# Altium schematic sheet ICWSHLP2_Adaptor_2x2_Transimpedance_ASIC2.SchDoc(ICWSHLP2_Adaptor_2x2_Transimpedance_ASIC2)
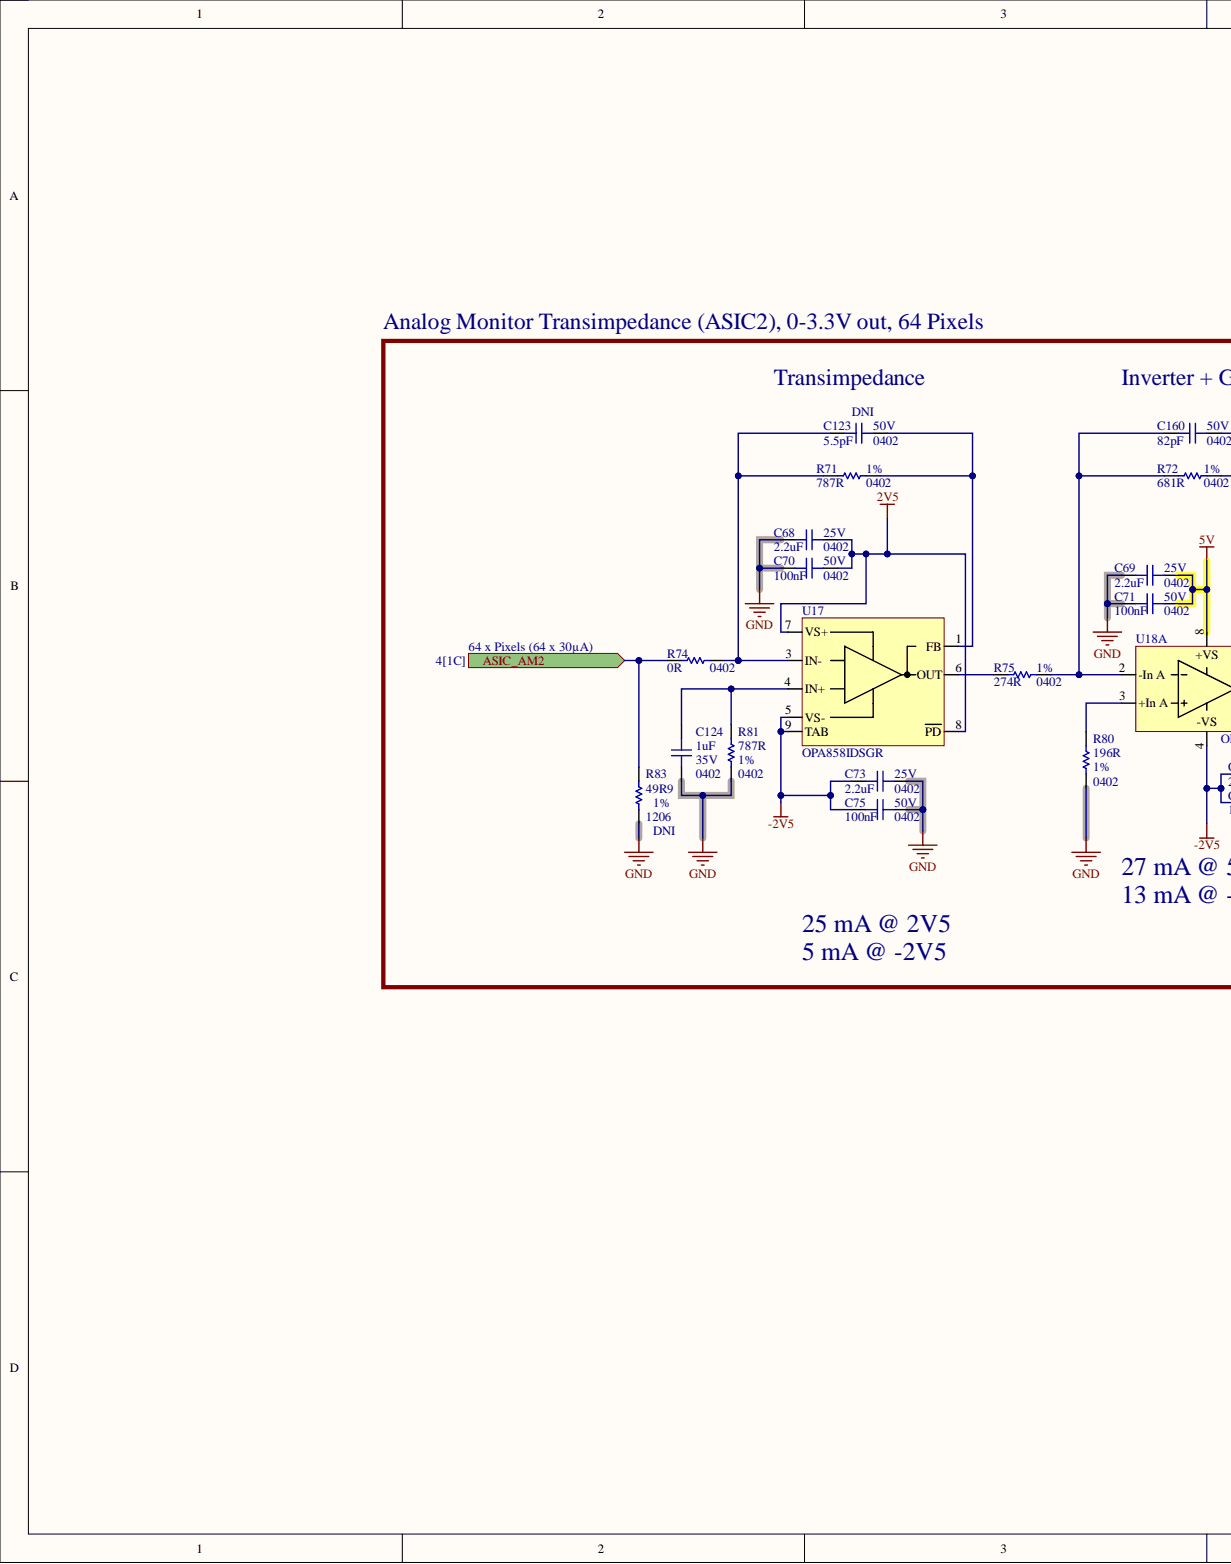
[diagram p0: ICWSHLP2_Adaptor_2x2_Transimpedance_ASIC2.SchDoc, left]
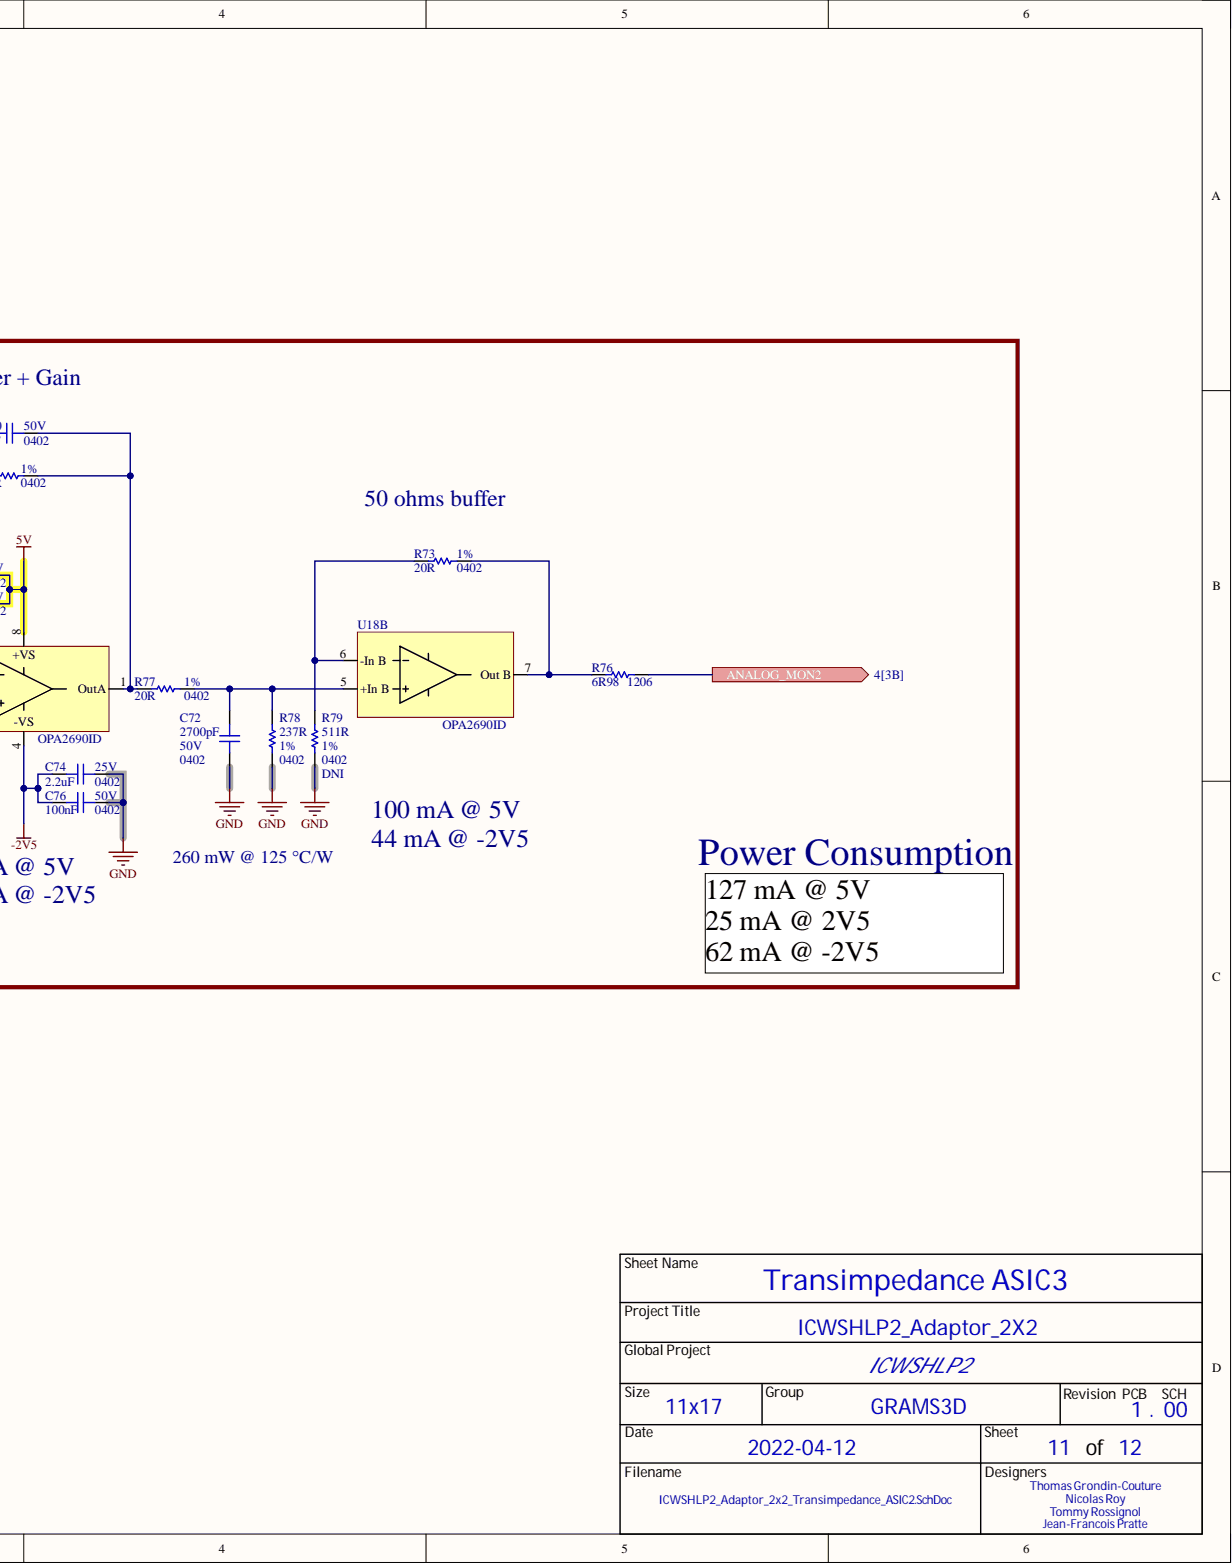
[diagram p1: ICWSHLP2_Adaptor_2x2_Transimpedance_ASIC2.SchDoc, right]

## Parts
C68  value 2.2uF  Package: 0402  Voltage Rating: 25V  [CAP_CER_0402]  (hidden comment: GRT155R61E225KE13D)
C69  value 2.2uF  Package: 0402  Voltage Rating: 25V  [CAP_CER_0402]  (hidden comment: GRT155R61E225KE13D)
C70  value 100nF  Package: 0402  Voltage Rating: 50V  [CAP_CER_0402]  (hidden comment: UMK105B7104KV-FR)
C71  value 100nF  Package: 0402  Voltage Rating: 50V  [CAP_CER_0402]  (hidden comment: UMK105B7104KV-FR)
C72  value 2700pF  Package: 0402  Voltage Rating: 50V  [CAP_CER_0402]  (hidden comment: C0402C272J5RAC7867)
C73  value 2.2uF  Package: 0402  Voltage Rating: 25V  [CAP_CER_0402]  (hidden comment: GRT155R61E225KE13D)
C74  value 2.2uF  Package: 0402  Voltage Rating: 25V  [CAP_CER_0402]  (hidden comment: GRT155R61E225KE13D)
C75  value 100nF  Package: 0402  Voltage Rating: 50V  [CAP_CER_0402]  (hidden comment: UMK105B7104KV-FR)
C76  value 100nF  Package: 0402  Voltage Rating: 50V  [CAP_CER_0402]  (hidden comment: UMK105B7104KV-FR)
C123  value 5.5pF  Assembly Note: DNI  Package: 0402  Voltage Rating: 50V  [CAP_CER_0402]  (hidden comment: GCQ1555C1H5R5BB01D)
C124  value 1uF  Package: 0402  Voltage Rating: 35V  [CAP_CER_0402]  (hidden comment: CL05A105KL5NRNC)
C160  value 82pF  Package: 0402  Voltage Rating: 50V  [CAP_CER_0402]  (hidden comment: 0402N820J500CT)
R71  value 787R  Package: 0402  Tolerance: 1%  [RES_0402]  (hidden comment: RC0402FR-07787RL)
R72  value 681R  Package: 0402  Tolerance: 1%  [RES_0402]  (hidden comment: RC0402FR-07681RL)
R73  value 20R  Package: 0402  Tolerance: 1%  [RES_0402]  (hidden comment: RC0402FR-0720RL)
R74  value 0R  Package: 0402  [RES_0402]  (hidden comment: RC0402JR-070RL)
R75  value 274R  Package: 0402  Tolerance: 1%  [RES_0402]  (hidden comment: ERJ-2RKF2740X)
R76  value 6R98  Package: 1206  [RES_1206]  (hidden comment: RC1206FR-076R98L)
R77  value 20R  Package: 0402  Tolerance: 1%  [RES_0402]  (hidden comment: RC0402FR-0720RL)
R78  value 237R  Package: 0402  Tolerance: 1%  [RES_0402]  (hidden comment: ERJ-2RKF2370X)
R79  value 511R  Assembly Note: DNI  Package: 0402  Tolerance: 1%  [RES_0402]  (hidden comment: RC0402FR-07511RL)
R80  value 196R  Package: 0402  Tolerance: 1%  [RES_0402]  (hidden comment: RC0402FR-07196RL)
R81  value 787R  Package: 0402  Tolerance: 1%  [RES_0402]  (hidden comment: RC0402FR-07787RL)
R83  value 49R9  Assembly Note: DNI  Package: 1206  Tolerance: 1%  [RES_1206]  (hidden comment: RNCP1206FTD49R9RC1206FR-076R98L)
U17  OPA858IDSGR  [AMP_HSP_OPA858IDSGR]
U18  OPA2690ID  [AMP_HSP_OPA2690ID]  (parts A,B)

## Ports
ANALOG_MON2, ASIC_AM2

## Nets
2V5: C68.2 C70.2 U17.7(VS+) U17.8(PD)
5V: C69.2 C71.2 U18.8
-2V5: C73.1 C74.1 C75.1 C76.1 U17.5(VS-) U17.9(TAB) U18.4
GND: C68.1 C69.1 C70.1 C71.1 C72.1 C73.2 C74.2 C75.2 C76.2 C124.1 R78.1 R79.1 R80.1 R81.1 R83.1
ANALOG_MON2: R76.1 ; port ANALOG_MON2
ASIC_AM2: R74.2 R83.2 ; port ASIC_AM2
NetC72_2: C72.2 R77.1 R78.2 U18.5(+In_B)
NetC123_1: C123.1 R71.1 U17.1(FB)
NetC123_2: C123.2 R71.2 R74.1 U17.3(IN-)
NetC124_2: C124.2 R81.2 U17.4(IN+)
NetC160_1: C160.1 R72.1 R77.2 U18.1(OutA)
NetC160_2: C160.2 R72.2 R75.1 U18.2(-In_A)
NetR73_1: R73.1 R76.2 U18.7(Out_B)
NetR73_2: R73.2 R79.2 U18.6(-In_B)
NetR75_2: R75.2 U17.6(OUT)
NetR80_2: R80.2 U18.3(+In_A)

## Library data of the parts
C68 C69 C73 C74 = CAP_CER_GRT155R61E225KE13D: CAP - CER 2.2uF ±10% 25V X5R - 0402; Manufacturer #1: Murata Electronics North America; Cryo: UNKNOWN; GRAMS Lib Spec: 2.8; Note: 2uF@1.5V 1uF@5V 0.55uF@10V; Polarized: No; Assembly Type: SMD; Component Kind: Standard; HelpURL: http://search.murata.co.jp/Ceramy/image/img/A01X/G101/ENG/GRT155R61E225KE13-01.pdf; Library Name: GRAMS_MASTER_LIB.IntLib; Magnetic Material: Unknown; Part Number #1: GRT155R61E225KE13D; Supplier 1: Digi-Key; Supplier Part Number 1: 490-12267-1-ND; Temperature: -55°C ~ 85°C; Tolerance: 10%; CreatedBy/Date: Keven Deslandes - 2018/12/18; VerifiedBy: Caroline Paulin - 23 janv 2019
C70 C71 C75 C76 = CAP_CER_UMK105B7104KV-FR: CAP - CER 100nF ±10% 50V X7R - 0402; Manufacturer #1: Taiyo Yuden; Cryo: UNKNOWN; GRAMS Lib Spec: 2.8; Note: DC bias losses = -25%@5V, -55%@10V; Polarized: No; Assembly Type: SMD; Component Kind: Standard; HelpURL: https://ds.yuden.co.jp/TYCOMPAS/ut/detail?pn=UMK105B7104KV-FR%20%20&u=M; Library Name: GRAMS_MASTER_LIB.IntLib; Magnetic Material: Unknown; Part Number #1: UMK105B7104KV-FR; Supplier 1: Digi-Key; Supplier Part Number 1: 587-3498-1-ND; Temperature: -55°C ~ 125°C; Tolerance: 10%; CreatedBy/Date: Keven Deslandes - 2018/12/18; VerifiedBy: Caroline Paulin - 23 janv 2019
C72 = CAP_CER_C0402C272J5RAC7867: CAP CER 2700PF 50V X7R 0402; Manufacturer #1: KEMET; Cryo: UNKNOWN; GRAMS Lib Spec: 2.8; Polarized: NO; Tolerance: 5%; Assembly Type: SMD; Component Kind: Standard; HelpURL: https://content.kemet.com/datasheets/KEM_C1002_X7R_SMD.pdf; Magnetic Material: UNKNOWN; Part Number #1: C0402C272J5RAC7867; Solder Paste Type: UNKNOWN; Stencil Thickness (Recommended): UNKNOWN; Supplier 1: Digi-Key; Supplier Part Number 1: 399-14438-1-ND; Temperature: -55°C ~ 125°C; CreatedBy/Date: Thomas G-C 2021-06-10; Library Name: Lib_temp_Thomas_GC.SchLib
C123 = CAP_CER_GCQ1555C1H5R5BB01D: CAP - CER 5.5pF +/- 0.1pF 50V C0G/NPO - 0402; Manufacturer #1: Murata Electronics North America; GRAMS Lib Spec: 2.8; Magnetic Material: Unknown; Polarized: NO; Assembly Type: SMD; Component Kind: Standard; HelpURL: https://search.murata.co.jp/Ceramy/image/img/A01X/G101/ENG/GCQ1555C1H5R5BB01-01.pdf; Library Name: GRAMS_MASTER_LIB.IntLib; Part Number #1: GCQ1555C1H5R5BB01D; Supplier 1: Digi-Key; Supplier Part Number 1: 490-17488-1-ND; Temperature: -55°C ~ 125°C; Tolerance: 0.1pF; CreatedBy/Date: Nicolas Roy 2019-04-17
C124 = CAP_CER_CL05A105KL5NRNC: CAP - CER 1uF 10% 35V X5R - 0402; Manufacturer #1: Samsung Electro-Mechanics; Cryo: UNKNOWN; GRAMS Lib Spec: 2.8; Polarized: NO; Assembly Type: SMD; Component Kind: Standard; HelpURL: http://www.samsungsem.com/kr/support/product-search/mlcc/__icsFiles/afieldfile/2018/06/19/CL05A105KL5NRNC.pdf; Library Name: GRAMS_MASTER_LIB.IntLib; Magnetic Material: Unknown; Part Number #1: CL05A105KL5NRNC; Supplier 1: Digi-Key; Supplier Part Number 1: 1276-6796-1-ND; Temperature: -55°C ~ 85°C; Tolerance: 10%; CreatedBy/Date: Nicolas Roy 2018-10-03; VerifiedBy: Caroline Paulin - 18 janv 2019
C160 = CAP_CER_0402N820J500CT: CAP CER 82PF 50V C0G/NP0 0402; Manufacturer #1: Walsin Technology Corporation; Cryo: UNKNOWN; GRAMS Lib Spec: 2.8; Polarized: NO; Tolerance: 5%; Assembly Type: SMD; Component Kind: Standard; HelpURL: http://www.passivecomponent.com/wp-content/uploads/2018/10/MLCC.pdf; Magnetic Material: UNKNOWN; Part Number #1: 0402N820J500CT; Solder Paste Type: UNKNOWN; Stencil Thickness (Recommended): UNKNOWN; Supplier 1: Digi-Key; Supplier Part Number 1: 1292-1355-1-ND; Temperature: -55°C ~ 125°C; CreatedBy/Date: Thomas G-C 2021-06-10; Library Name: Lib_temp_Thomas_GC.SchLib
R71 R81 = RES_RC0402FR-07787RL: RES - 787R 1% 1/16W - 0402; Manufacturer #1: Yageo; GRAMS Lib Spec: 2.8; Magnetic Material: Unknown; Polarized: No; Voltage Rating: 50V; Assembly Type: SMD; ClassName: Transimpedance_ASIC0; Component Kind: Standard; HelpURL: http://www.yageo.com/documents/recent/PYu-RC_Group_51_RoHS_L_10.pdf; Library Name: GRAMS_MASTER_LIB.IntLib; Part Number #1: RC0402FR-07787RL; Power Max: 1/16W; Supplier 1: Digi-Key; Supplier Part Number 1: YAG3233CT-ND; Temperature: -55°C ~ 155°C; CreatedBy/Date: Keven Deslandes 2018-11-20; VerifiedBy: Caroline Paulin - 8 janv 2019
R72 = RES_RC0402FR-07681RL: RES - 681R 1% 1/16W - 0402; Manufacturer #1: Yageo; Cryo: UNKNOWN; GRAMS Lib Spec: 2.8; Polarized: No; Voltage Rating: 50V; Assembly Type: SMD; Component Kind: Standard; HelpURL: http://www.yageo.com/documents/recent/PYu-RC_Group_51_RoHS_L_10.pdf; Library Name: GRAMS_MASTER_LIB.IntLib; Magnetic Material: Unknown; Part Number #1: RC0402FR-07681RL; Power Max: 1/16W; Supplier 1: Digi-Key; Supplier Part Number 1: YAG2375CT-ND; Temperature: -55°C ~ 155°C; CreatedBy/Date: Keven Deslandes 2018-11-02; VerifiedBy: Caroline Paulin - 8 janv 2019
R73 R77 = RES_RC0402FR-0720RL: RES - 20 OHM 1% 1/16W - 0402; Manufacturer #1: Yageo; Cryo: UNKNOWN; GRAMS Lib Spec: 2.8; Polarized: NO; Voltage Rating: 50V; Assembly Type: SMD; Component Kind: Standard; HelpURL: http://www.yageo.com/documents/recent/PYu-RC_Group_51_RoHS_L_10.pdf; Library Name: GRAMS_MASTER_LIB.IntLib; Magnetic Material: Unknown; Part Number #1: RC0402FR-0720RL; Power Max: 1/16W; Supplier 1: Digi-Key; Supplier Part Number 1: 311-20LRCT-ND; Temperature: -55°C ~ 155°C; CreatedBy/Date: Nicolas Roy/06 nov. 2018; VerifiedBy: Charles Richard 14-01-2019
R74 = RES_RC0402JR-070RL: RES - 0 OHM JUMPER 1/16W - 0402; Manufacturer #1: Yageo; GRAMS Lib Spec: 2.8; Magnetic Material: YES; Polarized: NO; Voltage Rating: 50V; Assembly Type: SMD; ClassName: Transimpedance_ASIC0; Component Kind: Standard; HelpURL: http://www.yageo.com/documents/recent/PYu-RC_Group_51_RoHS_L_9.pdf; Library Name: GRAMS_MASTER_LIB.IntLib; Part Number #1: RC0402JR-070RL; Power Max: 1/16W; Supplier 1: Digi-Key; Supplier Part Number 1: 311-0.0JRCT-ND; Temperature: -55°C ~ 155°C; Tolerance: N/A; CreatedBy/Date: Jonathan Bouchard 2018-07-05; VerifiedBy: Arnaud Samson 16-07-18
R75 = RES_ERJ-2RKF2740X: RES - 274R 1% 1/10W - 0402; Manufacturer #1: Panasonic Electronic Components; Cryo: UNKNOWN; GRAMS Lib Spec: 2.8; Polarized: No; Voltage Rating: 50V; Assembly Type: SMD; Component Kind: Standard; HelpURL: https://industrial.panasonic.com/cdbs/www-data/pdf/RDA0000/AOA0000C304.pdf; Library Name: GRAMS_MASTER_LIB.IntLib; Magnetic Material: Unknown; Part Number #1: ERJ-2RKF2740X; Power Max: 1/10W; Supplier 1: Digi-Key; Supplier Part Number 1: P274LCT-ND; Temperature: -55°C ~ 155°C; CreatedBy/Date: Keven Deslandes 2018-10-17; VerifiedBy: Caroline Paulin - 18/12/2018
R76 = RES_RC1206FR-076R98L: RES - 6R98 1% 1/4W  - 1206; Manufacturer #1: Yageo; Cryo: UNKNOWN; Current Rating: 2A; GRAMS Lib Spec: 2.9; Polarized: No; Voltage Rating: 200V; Assembly Type: SMD; Component Kind: Standard; HelpURL: https://www.yageo.com/upload/media/product/productsearch/datasheet/rchip/PYu-RC_Group_51_RoHS_L_11.pdf; Library Name: GRAMS_MASTER_LIB.IntLib; Magnetic Material: Unknown; Note: 1%; Part Number #1: RC1206FR-076R98L; Power Max: 1/4W; Supplier 1: Digi-Key; Supplier Part Number 1: 311-6.98FRTR-ND; Temperature: -55°C ~ 155°C; CreatedBy/Date: Thomas Grondin-Couture 2020-09-30
R78 = RES_ERJ-2RKF2370X: RES - 237R 1% 1/10W - 0402; Manufacturer #1: Panasonic Electronic Components; Cryo: UNKNOWN; GRAMS Lib Spec: 2.8; Polarized: No; Voltage Rating: 50V; Assembly Type: SMD; Component Kind: Standard; HelpURL: https://industrial.panasonic.com/cdbs/www-data/pdf/RDA0000/AOA0000C304.pdf; Library Name: GRAMS_MASTER_LIB.IntLib; Magnetic Material: Unknown; Part Number #1: ERJ-2RKF2370X; Power Max: 1/10W; Supplier 1: Digi-Key; Supplier Part Number 1: P237LCT-ND; Temperature: -55°C ~ 155°C; CreatedBy/Date: Keven Deslandes 2018-12-03; VerifiedBy: Caroline Paulin - 18/12/2018
R79 = RES_RC0402FR-07511RL: RES - 511R 1% 1/16W - 0402; Manufacturer #1: Yageo; Cryo: UNKNOWN; GRAMS Lib Spec: 2.8; Polarized: No; Voltage Rating: 50V; Assembly Type: SMD; Component Kind: Standard; HelpURL: http://www.yageo.com/documents/recent/PYu-RC_Group_51_RoHS_L_10.pdf; Library Name: GRAMS_MASTER_LIB.IntLib; Magnetic Material: Unknown; Part Number #1: RC0402FR-07511RL; Power Max: 1/16W; Supplier 1: Digi-Key; Supplier Part Number 1: 311-511LRCT-ND; Temperature: -55°C ~ 155°C; CreatedBy/Date: Keven Deslandes 2018-11-02; VerifiedBy: Caroline Paulin - 8 janv 2019
R80 = RES_RC0402FR-07196RL: RES - 196R 1% 1/16W - 0402; Manufacturer #1: Yageo; Cryo: UNKNOWN; GRAMS Lib Spec: 2.8; Polarized: No; Voltage Rating: 50V; Assembly Type: SMD; Component Kind: Standard; HelpURL: http://www.yageo.com/documents/recent/PYu-RC_Group_51_RoHS_L_9.pdf; Library Name: GRAMS_MASTER_LIB.IntLib; Magnetic Material: Unknown; Part Number #1: RC0402FR-07196RL; Power Max: 1/16W; Supplier 1: Digi-Key; Supplier Part Number 1: YAG3025CT-ND; Temperature: -55°C ~ 155°C; CreatedBy/Date: Keven Deslandes - 2018-11-15; VerifiedBy: Caroline Paulin - 18/12/2018
R83 = RES_RNCP1206FTD49R9: RES - 49R9 1% 1/2W  - 1206; Manufacturer #1: Stackpole Electronics Inc.; Cryo: UNKNOWN; Current Rating: 2A; GRAMS Lib Spec: 2.9; Polarized: No; Power Max: 1/4W; Voltage Rating: 200V; Assembly Type: SMD; Component Kind: Standard; HelpURL: https://www.seielect.com/catalog/sei-rncp.pdf; Library Name: GRAMS_MASTER_LIB.IntLib; Magnetic Material: Unknown; Part Number #1: RNCP1206FTD49R9; Supplier 1: Digi-Key; Supplier Part Number 1: RNCP1206FTD49R9TR-ND; Temperature: -55°C ~ 155°C; CreatedBy/Date: Thomas Grondin-Couture 2020-09-30
U17 = AMP_HSP_OPA858IDSGR: AMP - HSP 5.5GHZ LOW NOISE HIGH BW - WSON-8; Manufacturer #1: Texas Instruments; Cryo: UNKNOWN; Current Rating: 105mA; GRAMS Lib Spec: 2.9; Polarized: Yes; Voltage Rating: 3.3V~5.25V; Assembly Type: SMD; Component Kind: Standard; HelpURL: https://www.ti.com/lit/ds/symlink/opa858.pdf?ts=1600708573279&ref_url=https%253A%252F%252Fwww.ti.com%252Fproduct%252FOPA858; Library Name: GRAMS_MASTER_LIB.IntLib; Magnetic Material: Unknown; Package: WSON-8; Part Number #1: OPA858IDSGR; Supplier 1: Digi-Key; Supplier Part Number 1: 296-51512-2-ND; Temperature: -40°C ~ 125°C; CreatedBy/Date: Thomas Grondin-Couture - 2020/09/24; VerifiedBy: C.Paulin - 28-10-2020
U18 = AMP_HSP_OPA2690ID: AMP - Dual HIGH SPEED 220MHZ 1800V/us ±2.5V to ±6V CFB - 8-SO; Manufacturer #1: Texas Instruments; Cryo: UNKNOWN; Current Rating: 190mA; GRAMS Lib Spec: 2.9; Polarized: Yes; Voltage Rating: 5 V ~ 12 V, ±2.5 V ~ 6 V; Assembly Type: SMD; Component Kind: Standard; HelpURL: https://www.ti.com/lit/ds/symlink/opa2690.pdf?ts=1601046053325&ref_url=https%253A%252F%252Fwww.ti.com%252Famplifier-circuit%252Fop-amps%252Fhigh-speed%252Fproducts.html; Library Name: GRAMS_MASTER_LIB.IntLib; Magnetic Material: Unknown; Package: 8-SOIC; Part Number #1: OPA2690ID; Supplier 1: Digi-Key; Supplier Part Number 1: 296-13465-5-ND; Temperature: -40°C ~ 85°C; CreatedBy/Date: Thomas Grondin-Couture - 2020/09/25
